# Altium schematic sheet 13_CEP.SchDoc
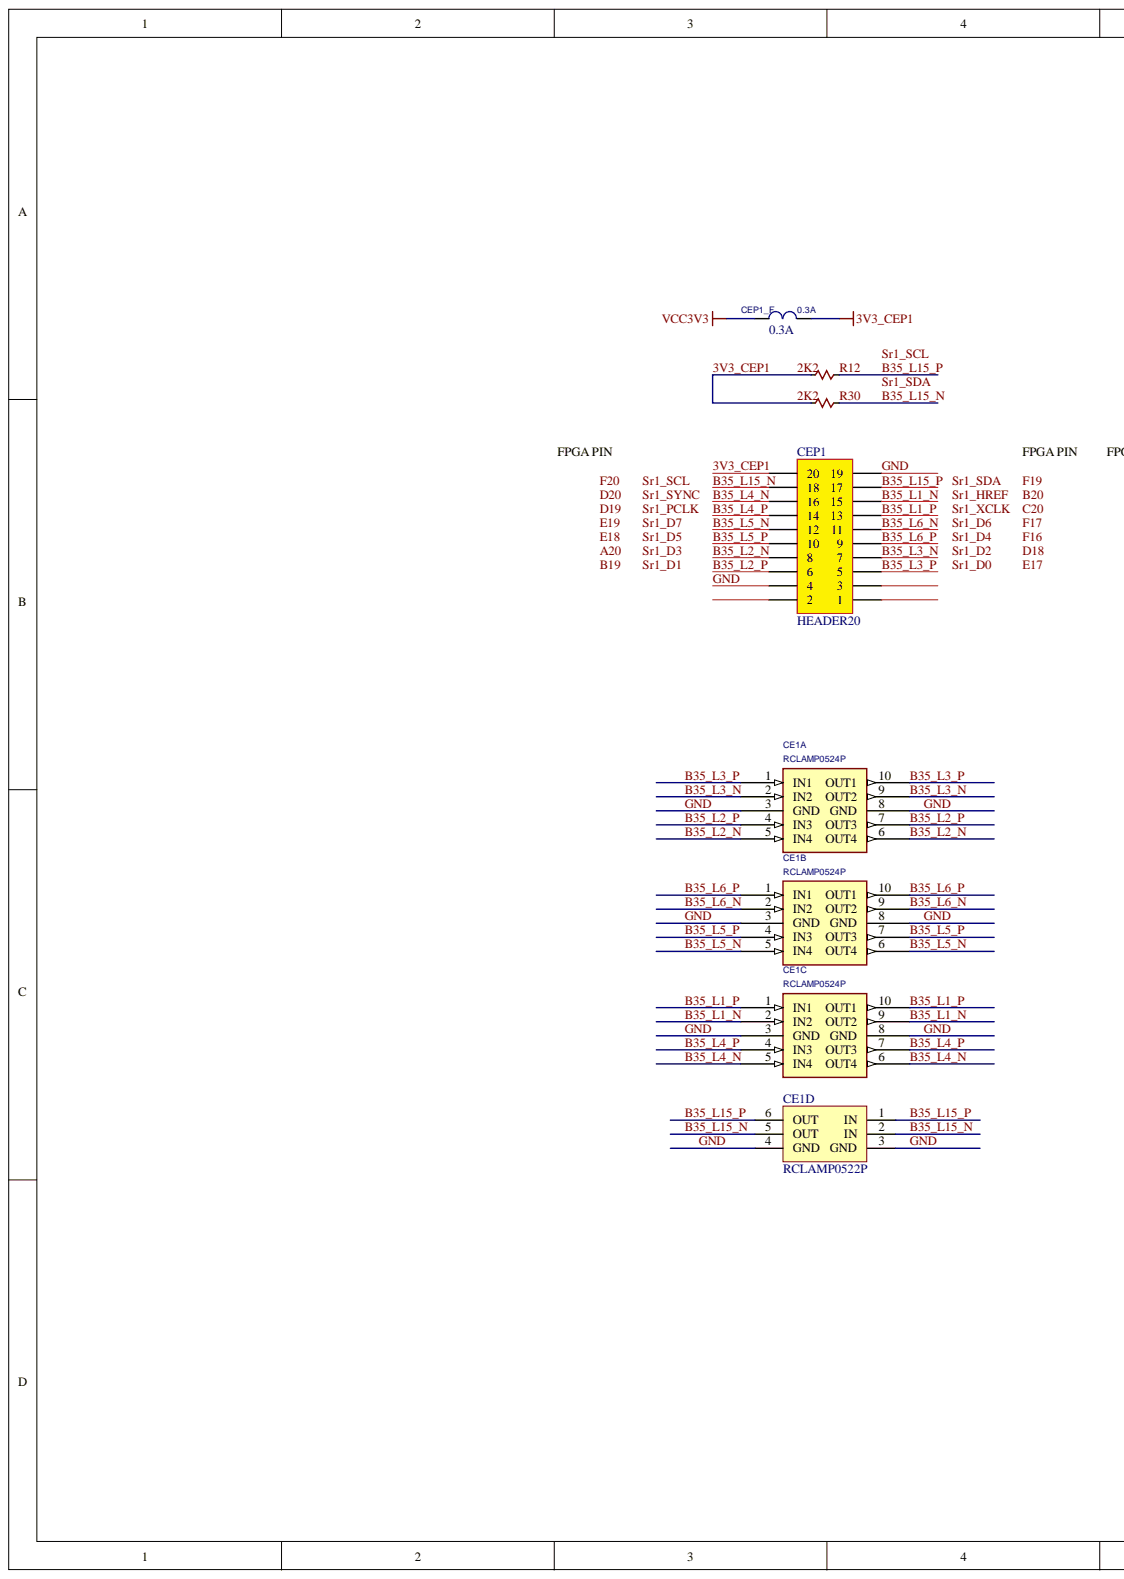
[diagram p0: 13_CEP.SchDoc, left]
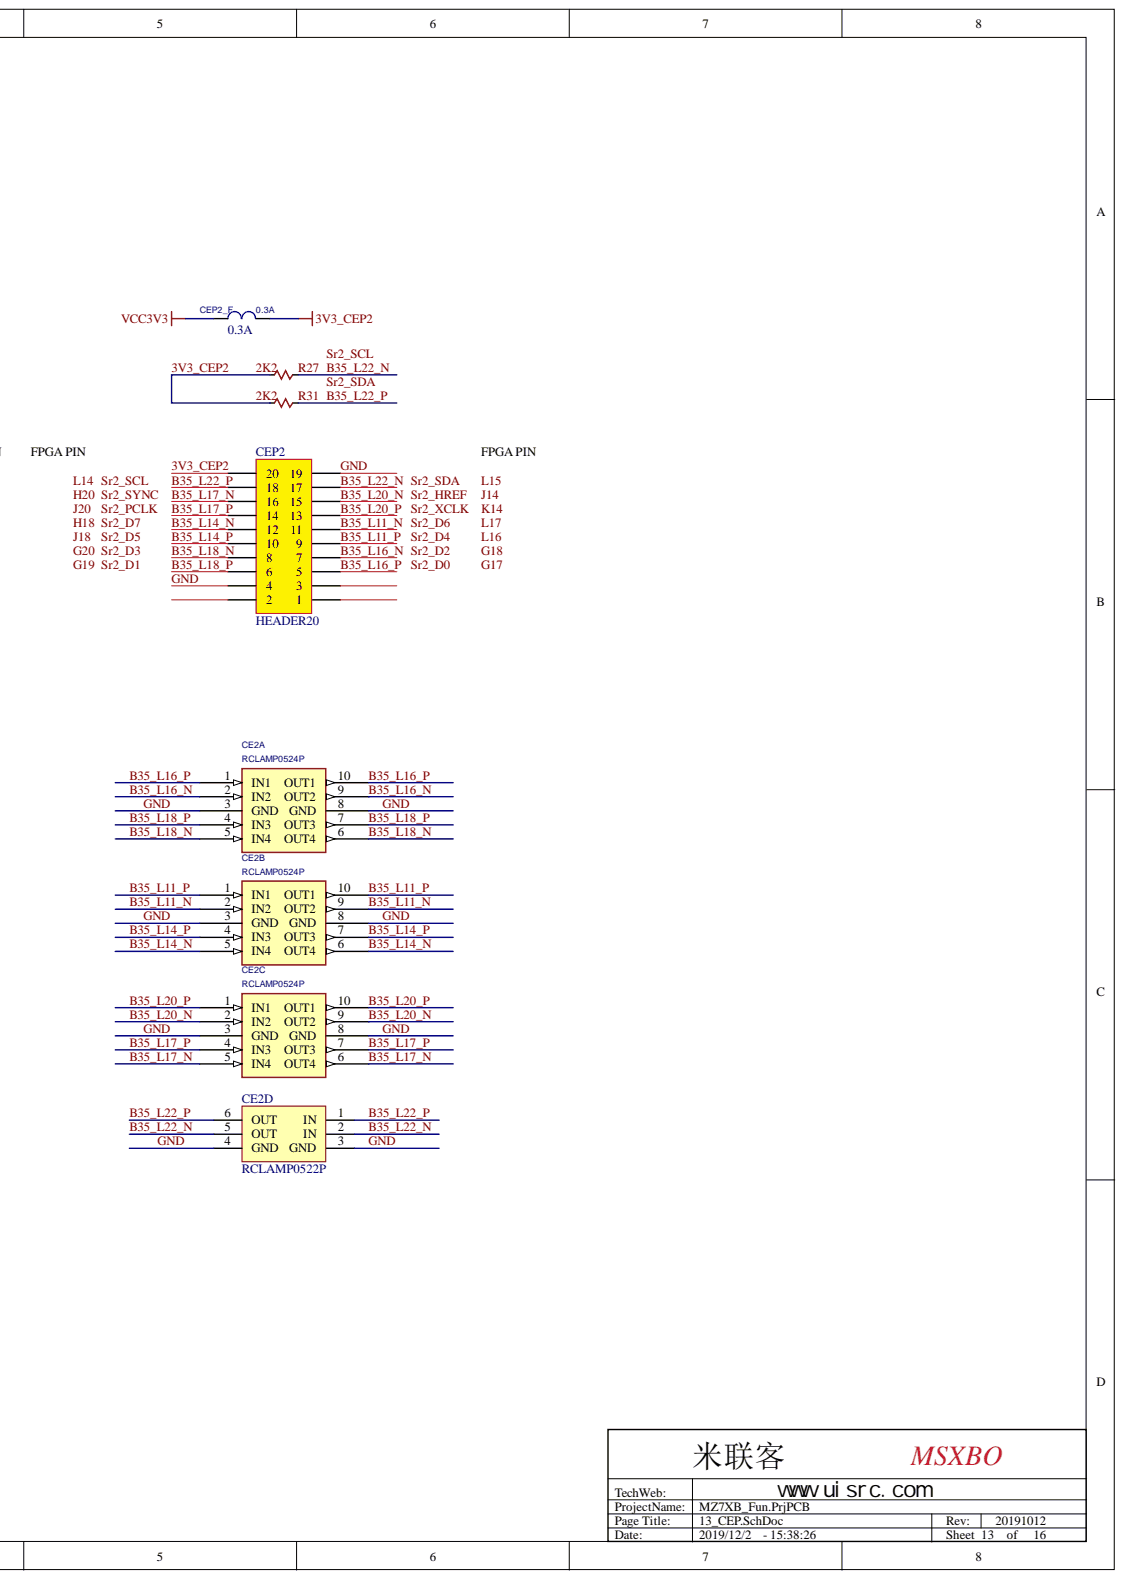
[diagram p1: 13_CEP.SchDoc, right]

## Parts
CE1A  RCLAMP0524P  [SLP2510P8_10]
CE1B  RCLAMP0524P  [SLP2510P8_10]
CE1C  RCLAMP0524P  [SLP2510P8_10]
CE1D  RCLAMP0522P  [SLP1610P4_6]
CE2A  RCLAMP0524P  [SLP2510P8_10]
CE2B  RCLAMP0524P  [SLP2510P8_10]
CE2C  RCLAMP0524P  [SLP2510P8_10]
CE2D  RCLAMP0522P  [SLP1610P4_6]
CEP1  HEADER20  [HEADER10X2X2.5]
CEP1_F  0.3A  [CAP0603]
CEP2  HEADER20  [HEADER10X2X2.5]
CEP2_F  0.3A  [CAP0603]
R12  2K2  [RES0402]
R27  2K2  [RES0402]
R30  2K2  [RES0402]
R31  2K2  [RES0402]

## Nets
VCC3V3: CEP1_F.1 CEP2_F.1
3V3_CEP1: CEP1.20 CEP1_F.2 R12.1 R30.1
3V3_CEP2: CEP2.20 CEP2_F.2 R27.1 R31.1
B35_L1_N: CE1C.2(IN2) CE1C.9(OUT2) CEP1.15
B35_L1_P: CE1C.1(IN1) CE1C.10(OUT1) CEP1.13
B35_L2_N: CE1A.5(IN4) CE1A.6(OUT4) CEP1.8
B35_L2_P: CE1A.4(IN3) CE1A.7(OUT3) CEP1.6
B35_L3_N: CE1A.2(IN2) CE1A.9(OUT2) CEP1.7
B35_L3_P: CE1A.1(IN1) CE1A.10(OUT1) CEP1.5
B35_L4_N: CE1C.5(IN4) CE1C.6(OUT4) CEP1.16
B35_L4_P: CE1C.4(IN3) CE1C.7(OUT3) CEP1.14
B35_L5_N: CE1B.5(IN4) CE1B.6(OUT4) CEP1.12
B35_L5_P: CE1B.4(IN3) CE1B.7(OUT3) CEP1.10
B35_L6_N: CE1B.2(IN2) CE1B.9(OUT2) CEP1.11
B35_L6_P: CE1B.1(IN1) CE1B.10(OUT1) CEP1.9
B35_L11_N: CE2B.2(IN2) CE2B.9(OUT2) CEP2.11
B35_L11_P: CE2B.1(IN1) CE2B.10(OUT1) CEP2.9
B35_L14_N: CE2B.5(IN4) CE2B.6(OUT4) CEP2.12
B35_L14_P: CE2B.4(IN3) CE2B.7(OUT3) CEP2.10
B35_L15_N: CE1D.2(IN) CE1D.5(OUT) CEP1.18 R30.2
B35_L15_P: CE1D.1(IN) CE1D.6(OUT) CEP1.17 R12.2
B35_L16_N: CE2A.2(IN2) CE2A.9(OUT2) CEP2.7
B35_L16_P: CE2A.1(IN1) CE2A.10(OUT1) CEP2.5
B35_L17_N: CE2C.5(IN4) CE2C.6(OUT4) CEP2.16
B35_L17_P: CE2C.4(IN3) CE2C.7(OUT3) CEP2.14
B35_L18_N: CE2A.5(IN4) CE2A.6(OUT4) CEP2.8
B35_L18_P: CE2A.4(IN3) CE2A.7(OUT3) CEP2.6
B35_L20_N: CE2C.2(IN2) CE2C.9(OUT2) CEP2.15
B35_L20_P: CE2C.1(IN1) CE2C.10(OUT1) CEP2.13
B35_L22_N: CE2D.2(IN) CE2D.5(OUT) CEP2.17 R27.2
B35_L22_P: CE2D.1(IN) CE2D.6(OUT) CEP2.18 R31.2
GND: CE1A.3(GND) CE1A.8(GND) CE1B.3(GND) CE1B.8(GND) CE1C.3(GND) CE1C.8(GND) CE1D.3(GND) CE1D.4(GND) CE2A.3(GND) CE2A.8(GND) CE2B.3(GND) CE2B.8(GND) CE2C.3(GND) CE2C.8(GND) CE2D.3(GND) CE2D.4(GND) CEP1.4 CEP1.19 CEP2.4 CEP2.19
A20:
B19:
B20:
C20:
D18:
D19:
D20:
E17:
E18:
E19:
F16:
F17:
F19:
F20:
G17:
G18:
G19:
G20:
H18:
H20:
J14:
J18:
J20:
K14:
L14:
L15:
L16:
L17:
SR1_D0:
SR1_D1:
SR1_D2:
SR1_D3:
SR1_D4:
SR1_D5:
SR1_D6:
SR1_D7:
SR1_HREF:
SR1_PCLK:
SR1_SCL:
SR1_SDA:
SR1_SYNC:
SR1_XCLK:
SR2_D0:
SR2_D1:
SR2_D2:
SR2_D3:
SR2_D4:
SR2_D5:
SR2_D6:
SR2_D7:
SR2_HREF:
SR2_PCLK:
SR2_SCL:
SR2_SDA:
SR2_SYNC:
SR2_XCLK:

Not connected: CEP1 1 2 3; CEP2 1 2 3

## Library data of the parts
CEP1 CEP2 = HEADER10X2: Component Kind: Standard; Library Name: jinrylib.IntLib
CEP1_F CEP2_F = INDUCTOR1: CURRENT: LMAX; DIELECTRIC: DSMAX; DIST: FLAT; IL1: 0; PSpiceTemplate: L^@REFDES %1 %2 ?TOLERANCE|L^@REFDES| @VALUE ?IC/IC=@IC/ ?TOLERANCE|\n.model l^@REFDES IND L=1 DEV=@TOLERANCE% TC1=@TC1 TC2=@TC2 IL1=@IL1 IL2=@IL2|; Component Kind: Standard; IL2: 0; Simulation: INDUCTOR1; TC1: 0; TC2: 0; Value: 0.3A
R12 R27 R30 R31 = RESISTOR: Generic Resistor; Value: 390; Component Kind: Standard; Library Name: Digilent.IntLib
CE1A CE1B CE1C CE2A CE2B CE2C = RCLAMP0524P: Component Kind: Standard
CE1D CE2D = RCLAMP0522P: Component Kind: Standard; Library Name: MiZ7020N_定制.SCHLIB
